# Altium schematic sheet Secondary.SchDoc
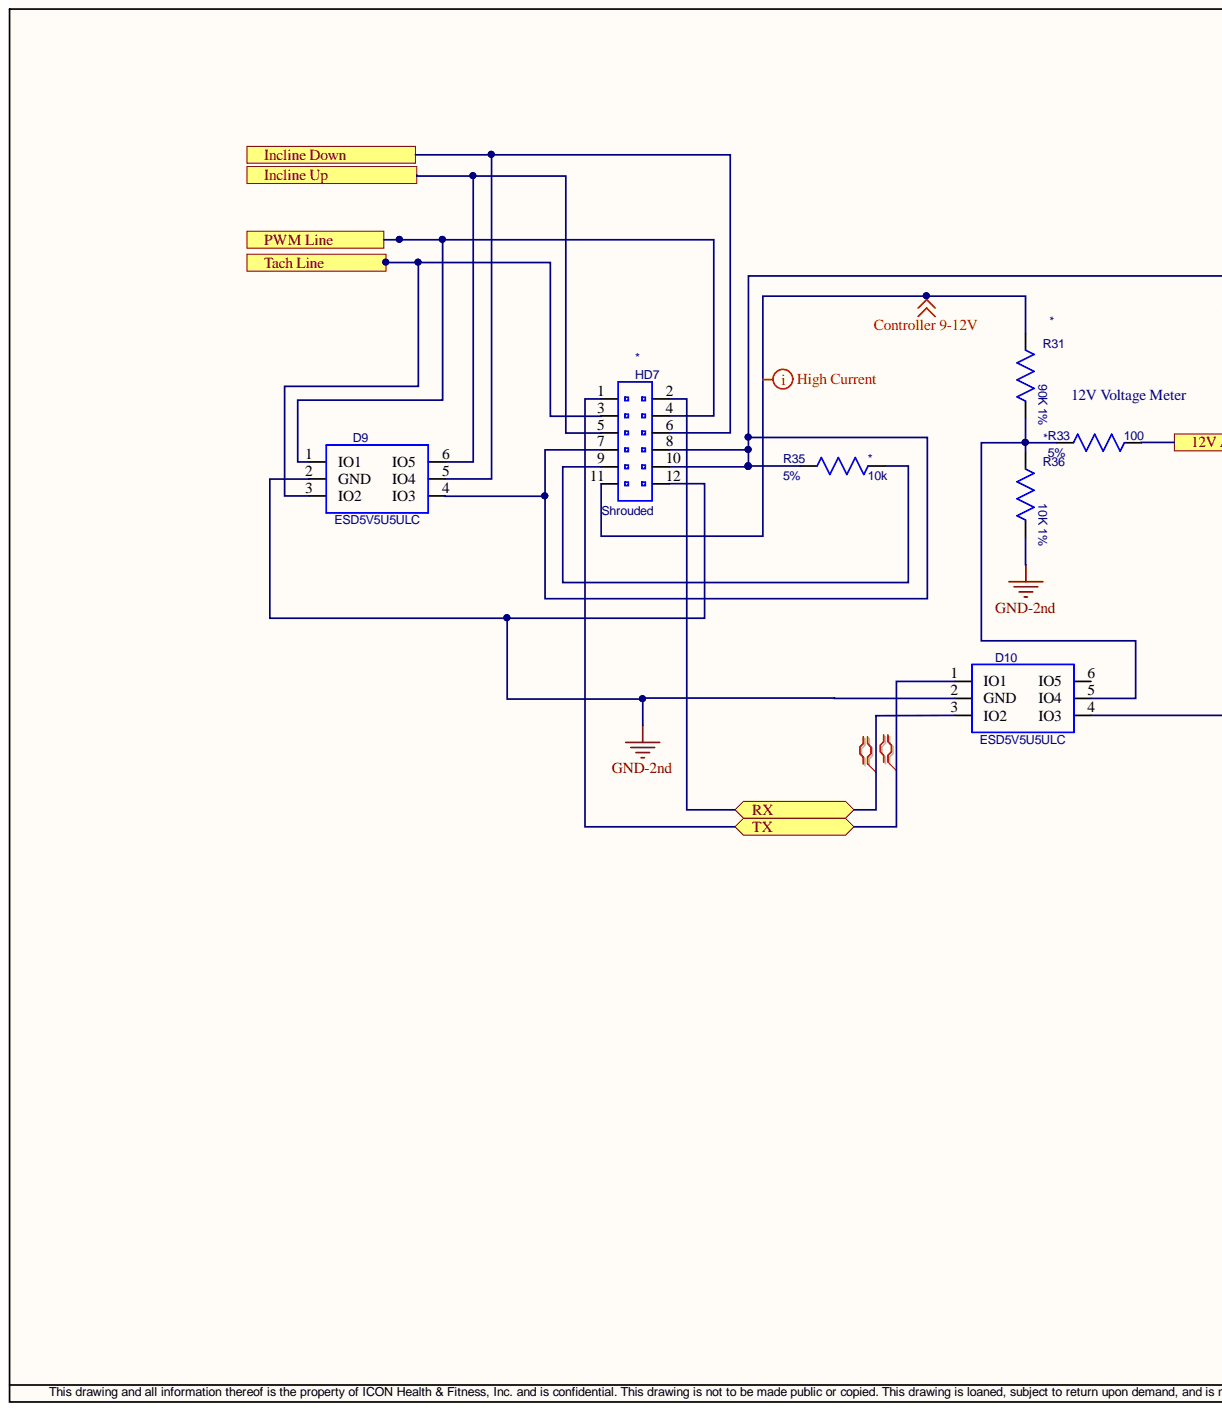
[diagram p0: Secondary.SchDoc, left]
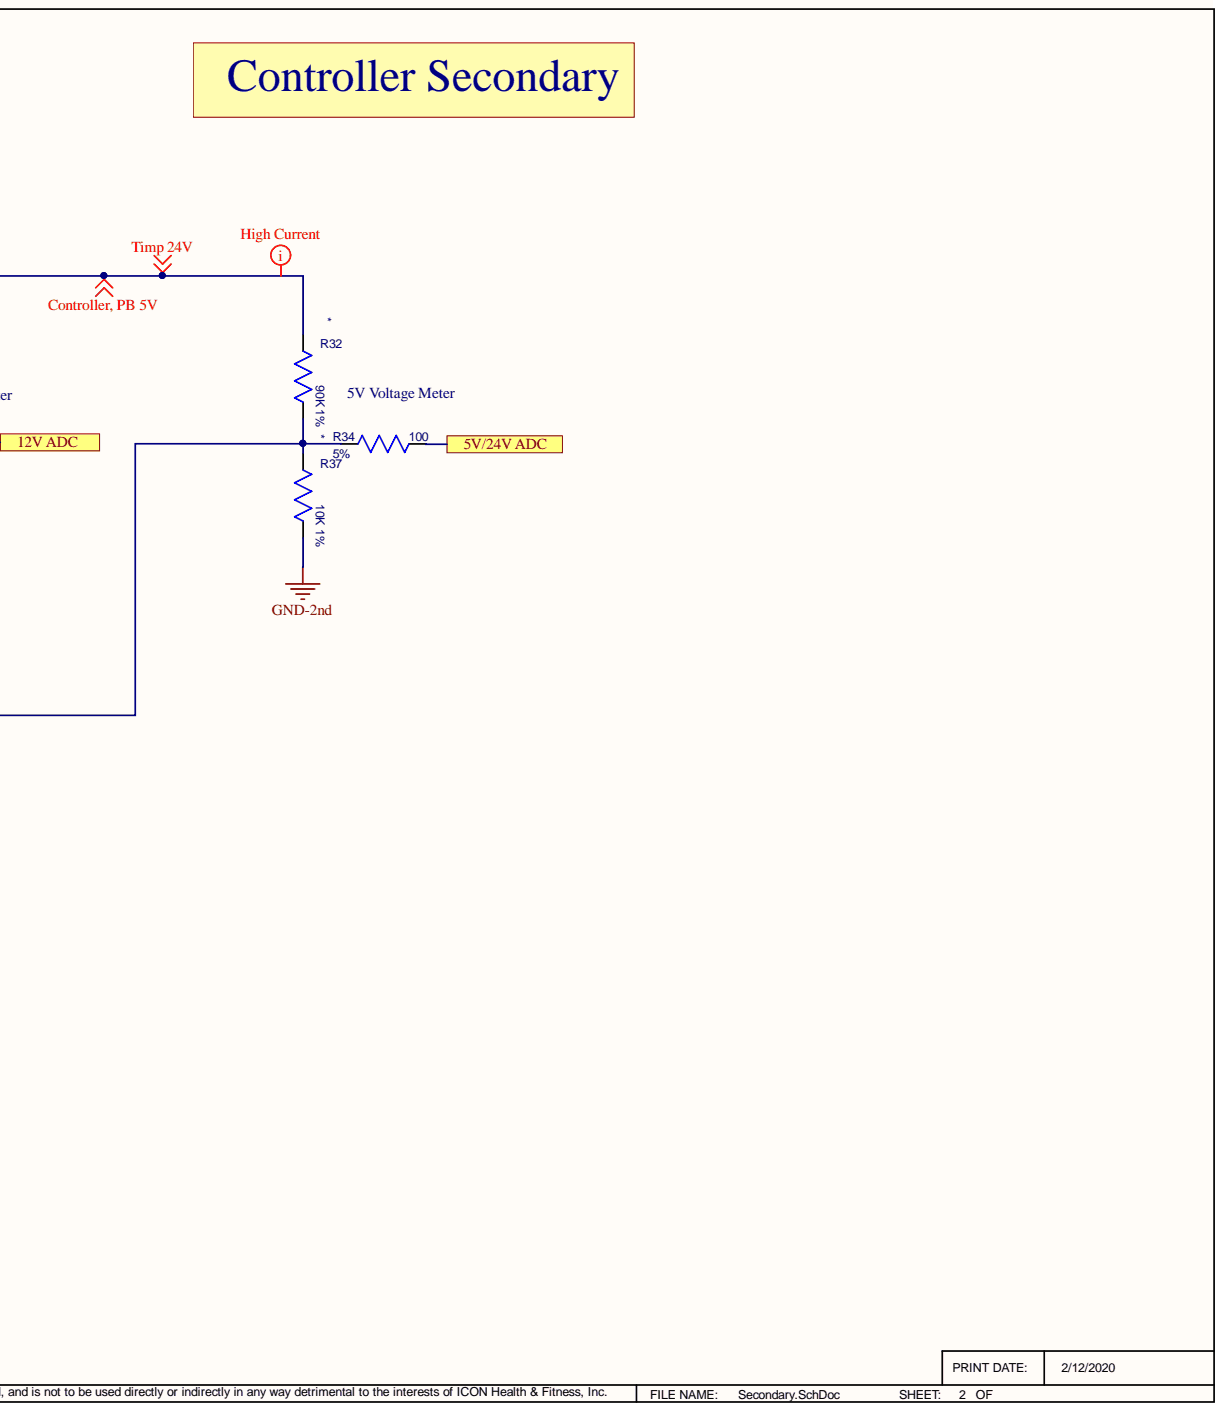
[diagram p1: Secondary.SchDoc, right]

## Parts
D9  ESD5V5U5ULC  [SOT457-6]
D10  ESD5V5U5ULC  [SOT457-6]
HD7  HeaderType: Shrouded  [HDR_2.5MM_12PIN_JST_XAD]  (hidden comment: HDR_12PIN_DUALROW)
R31  value 90K  Tolerance: 1%  [RC0603REFLOW-IPC]  (hidden comment: R0603REFLOW_1%)
R32  value 90K  Tolerance: 1%  [RC0603REFLOW-IPC]  (hidden comment: R0603REFLOW_1%)
R33  value 100  Tolerance: 5%  [0201]  (hidden comment: Resistor_0201_5%)
R34  value 100  Tolerance: 5%  [0201]  (hidden comment: Resistor_0201_5%)
R35  value 10k  Tolerance: 5%  [0402]  (hidden comment: RESISTOR_SMT_5%)
R36  value 10K 1%  [RC0603REFLOW-IPC]  (hidden comment: R0603REFLOW_1%)
R37  value 10K 1%  [RC0603REFLOW-IPC]  (hidden comment: R0603REFLOW_1%)

## Ports
5V/24V ADC, 12V ADC, Incline Down, Incline Up, PWM Line, RX, Tach Line, TX

## Nets
Controller 9-12V: HD7.11 R31.2
Controller, PB 5V: D9.4(IO3) HD7.7 HD7.8 HD7.10 R32.2 R35.2
GND-2nd: D9.2(GND) D10.2(GND) HD7.12 R36.1 R37.1
5V/24V ADC: R34.1 ; port 5V/24V ADC
12V ADC: R33.1 ; port 12V ADC
Incline Down: D9.5(IO4) HD7.6 ; port Incline Down
Incline Up: D9.6(IO5) HD7.5 ; port Incline Up
PWM Line: D9.1(IO1) HD7.4 ; port PWM Line
RX: D10.3(IO2) HD7.2 ; port RX
Tach Line: D9.3(IO2) HD7.3 ; port Tach Line
TX: D10.1(IO1) HD7.1 ; port TX
NetD10_4: D10.4(IO3) R32.1 R34.2 R37.2
NetD10_5: D10.5(IO4) R31.1 R33.2 R36.2
NetHD7_9: HD7.9 R35.1

Not connected: D10 6(IO5)

## Library data of the parts
D9 D10 = 325030: Diode, ESD5V5U5ULC, TVS, 5V, TSOP6, Infineon: ESD5V5U5ULC; Database Table Name: AltiumComponents; IDFCOMP: 0; Component Kind: Standard; ComponentLink1Description: Datasheet; ComponentLink1URL: \\iconfitness.com\loganfiles\RND Departments\electronics\accel_lib\datasheets\Infineon-ESD5V5U5ULC-DS-v01_04-EN.pdf; ComponentLink4Description: PDM Parts Maintenance; ComponentLink4URL: https://pdm.iconfitness.com/PDM/EBOM/PartsMaintenance/PartsMaintenance.aspx?Editplidx=325030; ComponentType: Diode; Cost: 0.08; Datasheet: \\langley\electronics\accel_lib\datasheets\D\PESDXS4UD-NXP.pdf; DiodeType: TVS; ModifyDate: 07/31/2009 12:30:23; Package: TSOP6; plIDX: 325030; Value: ESD5V5U5ULC; Vendor: Infineon: ESD5V5U5ULC; Voltage: 5V; CheckedBy: T. Hayes; CreatedBy: Rui Zhang; LastUpdatedBy: Rui Zhang; Library Name: bome.DbLib
HD7 = 349864: Header, 12 Pins, 2.50mm, Vertical, Through hole, dual row, Shrouded, JST: B12B-XADSS-N-A; Database Table Name: AltiumComponents; Component Kind: Standard; ComponentLink1Description: Datasheet; ComponentLink1URL: \\iconfitness.com\loganfiles\RND Departments\electronics\accel_lib\Datasheets\eXAD1.pdf; ComponentLink4Description: PDM Parts Maintenance; ComponentLink4URL: https://pdm.iconfitness.com/PDM/EBOM/PartsMaintenance/PartsMaintenance.aspx?Editplidx=349864; ComponentType: Header; Cost: 0; LeadSpacing: 2.50mm; Package: Vertical, Through hole, dual row; Pins: 12; plIDX: 349864; Value: B12B-XADSS-N-A; Vendor: JST: B12B-XADSS-N-A; CreatedBy: Rui Zhang; LastUpdatedBy: Rui Zhang; Library Name: bome.DbLib
R31 R32 = 306655: Resistor, 90K, ThickFilm, 1%, 0603, 1/10W, Any; Database Table Name: AltiumComponents; Component Kind: Standard; ComponentLink1Description: Datasheet; ComponentLink1URL: \\iconfitness.com\loganfiles\RND Departments\electronics\accel_lib\Datasheets\yageodocoutput.pdf; ComponentLink4Description: PDM Parts Maintenance; ComponentLink4URL: https://pdm.iconfitness.com/PDM/EBOM/PartsMaintenance/PartsMaintenance.aspx?Editplidx=306655; ComponentType: Resistor; Composition: ThickFilm; Cost: 0.0004; Package: 0603; plIDX: 306655; Vendor: Any; Wattage: 1/10W; CheckedBy: Michael Angeli; CreatedBy: Michael Angeli; LastUpdatedBy: Michael Angeli; Library Name: bome.DbLib
R33 R34 = 304094: Resistor, 100, ThickFilm, 5%, 0201, 1/20W, 2, Any; Database Table Name: AltiumComponents; Component Kind: Standard; ComponentLink4Description: PDM Parts Maintenance; ComponentLink4URL: https://pdm.iconfitness.com/PDM/EBOM/PartsMaintenance/PartsMaintenance.aspx?Editplidx=304094; ComponentType: Resistor; Composition: ThickFilm; Cost: 0; ModifyDate: 4/13/10; Package: 0201; Pins: 2; plIDX: 304094; Vendor: Any; Wattage: 1/20W; CheckedBy: gdeguzman; CreatedBy: Sara Lin; LastUpdatedBy: Sara Lin; Library Name: bome.DbLib
R35 = 19590: Resistor, 10k, ThickFilm, 5%, 0402, 1/10W, Any; Database Table Name: AltiumComponents; Component Kind: Standard; ComponentLink4Description: PDM Parts Maintenance; ComponentLink4URL: https://pdm.iconfitness.com/PDM/EBOM/PartsMaintenance/PartsMaintenance.aspx?Editplidx=19590; ComponentType: Resistor; Composition: ThickFilm; Cost: 0.0004; Package: 0402; plIDX: 19590; Vendor: Any; Wattage: 1/10W; CheckedBy: gdeguzman; LastUpdatedBy: Mike Tyger; Library Name: bome.DbLib
R36 R37 = 5188: Resistor, 10K 1%, ThickFilm, 1%, 0603, 1/10W, Any; Database Table Name: AltiumComponents; Component Kind: Standard; ComponentLink4Description: PDM Parts Maintenance; ComponentLink4URL: https://pdm.iconfitness.com/PDM/EBOM/PartsMaintenance/PartsMaintenance.aspx?Editplidx=5188; ComponentType: Resistor; Composition: ThickFilm; Cost: 0.0004; Package: 0603; plIDX: 5188; Vendor: Any; Wattage: 1/10W; CheckedBy: Michael Angeli; Library Name: bome.DbLib
